# Altium schematic sheet 07_MOTOR_MAG.SchDoc
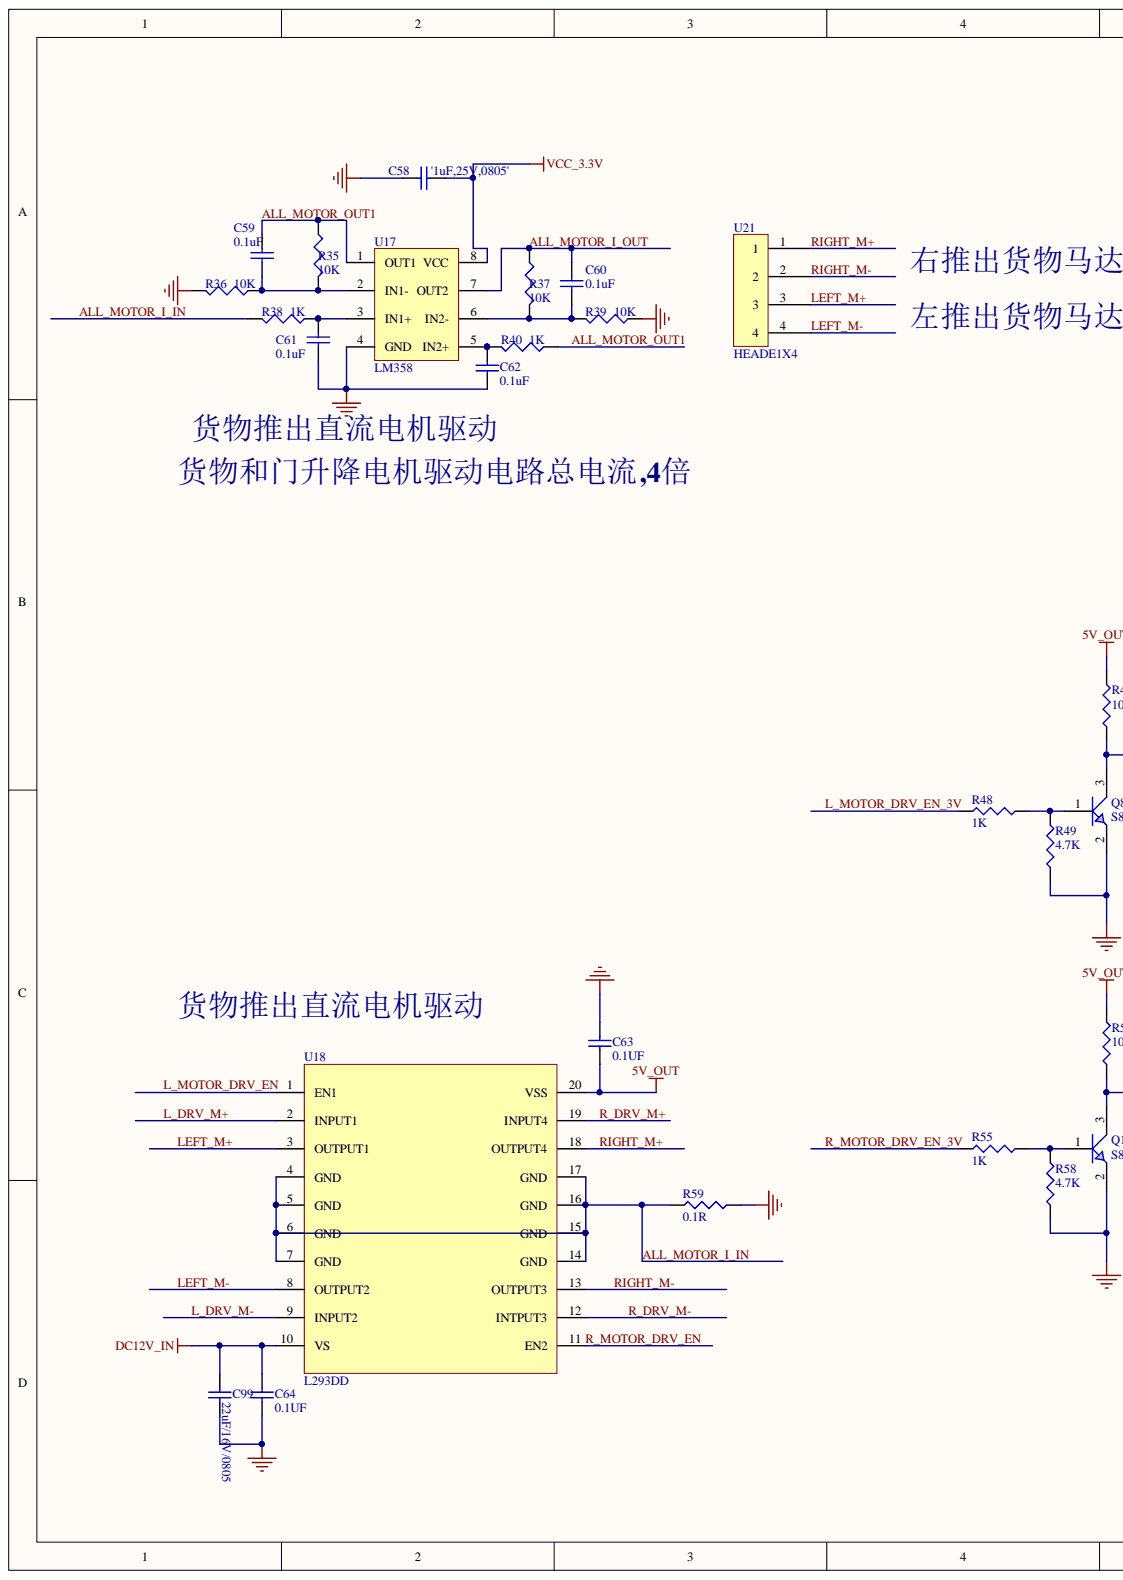
[diagram p0: 07_MOTOR_MAG.SchDoc, left]
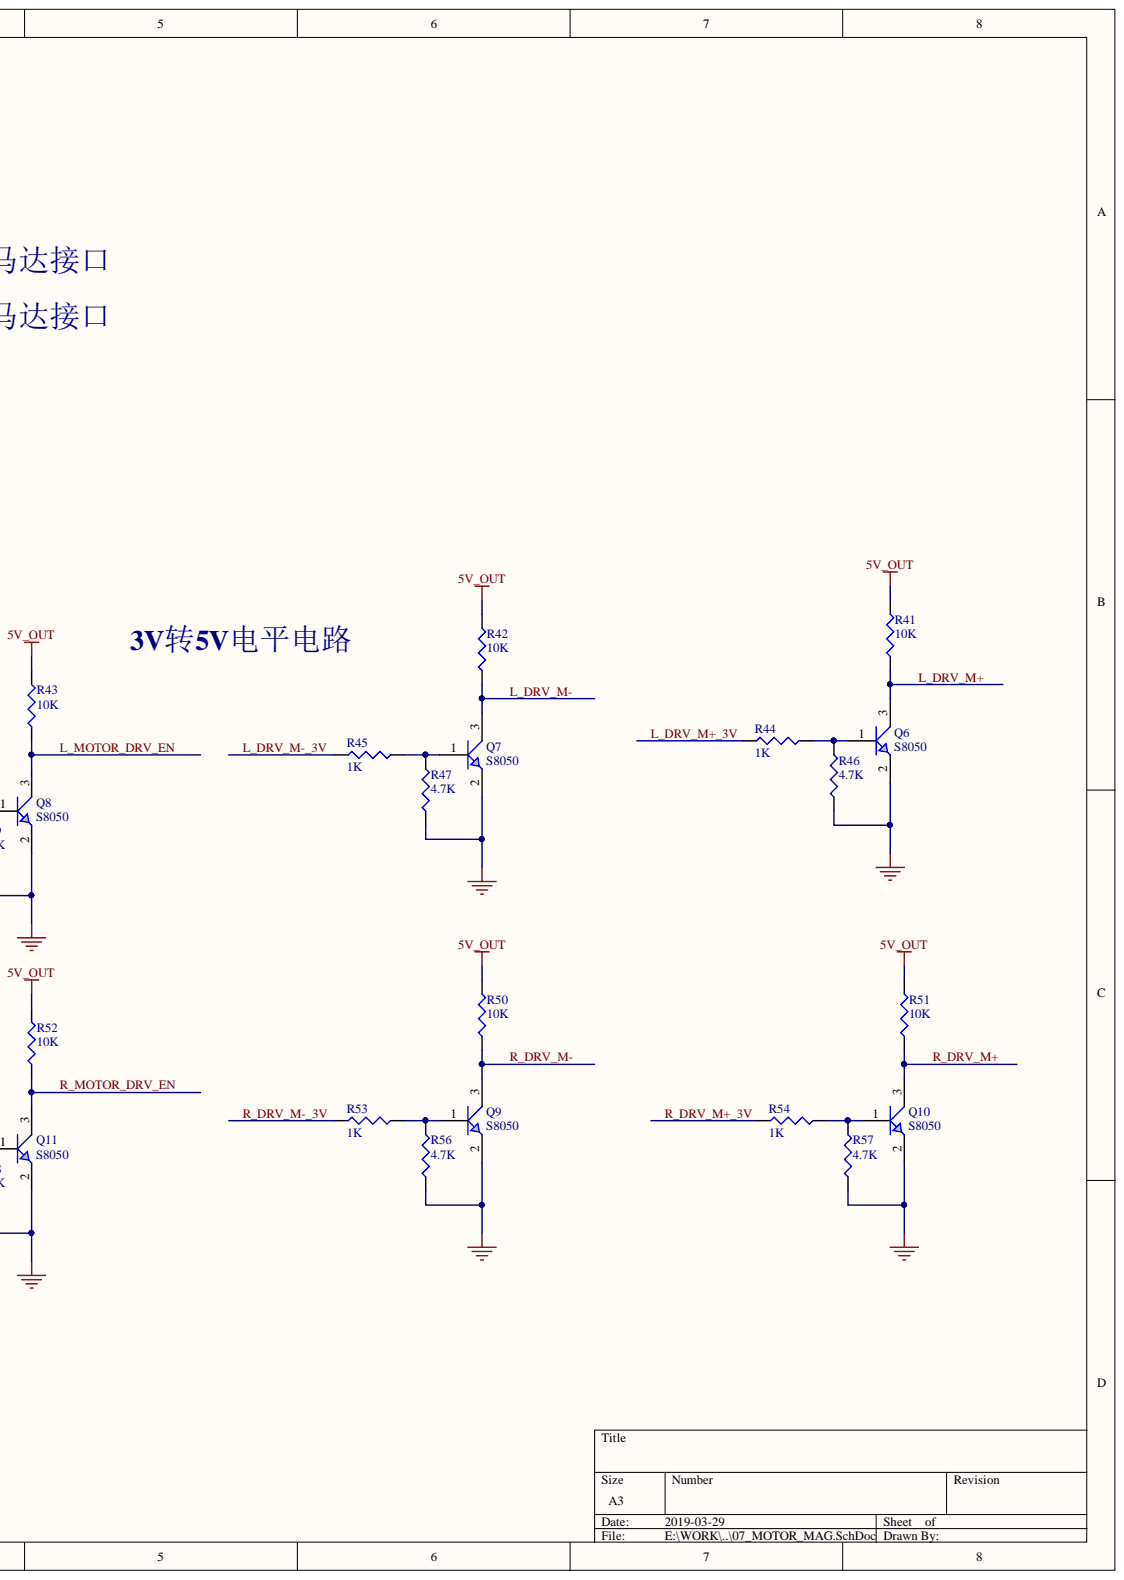
[diagram p1: 07_MOTOR_MAG.SchDoc, right]

## Parts
C58  value '1uF,25V,0805'  [0603_C]  (hidden comment: 1UF)
C59  0.1uF  [0603_C]
C60  0.1uF  [0603_C]
C61  0.1UF  [0603_C]
C62  0.1UF  [0603_C]
C63  0.1UF  [0603_C]
C64  0.1UF  [0603_C]
C99  22uF/16V/0805  [1206_C]
Q6  S8050  [SOT23]
Q7  S8050  [SOT23]
Q8  S8050  [SOT23]
Q9  S8050  [SOT23]
Q10  S8050  [SOT23]
Q11  S8050  [SOT23]
R35  10K  [0603_R]
R36  10K  [0603_R]
R37  10K  [0603_R]
R38  1K  [0603_R]
R39  10K  [0603_R]
R40  1K  [0603_R]
R41  10K  [0603_R]
R42  10K  [0603_R]
R43  10K  [0603_R]
R44  1K  [0603_R]
R45  1K  [0603_R]
R46  4.7K  [0603_R]
R47  4.7K  [0603_R]
R48  1K  [0603_R]
R49  4.7K  [0603_R]
R50  10K  [0603_R]
R51  10K  [0603_R]
R52  10K  [0603_R]
R53  1K  [0603_R]
R54  1K  [0603_R]
R55  1K  [0603_R]
R56  4.7K  [0603_R]
R57  4.7K  [0603_R]
R58  4.7K  [0603_R]
R59  0.1R  [1206_R]
U17  LM358  [SOP8]
U18  L293DD  [SOP20]
U21  value HEADE1X4  [HEADER1X4_1.25mm-V]  (hidden comment: IC)

## Nets
5V_OUT: C63.2 R41.2 R42.2 R43.2 R50.2 R51.2 R52.2 U18.20(VSS)
DC12V_IN: C64.2 C99.2 U18.10(VS)
GND: C58.1 C61.2 C62.2 C63.1 C64.1 C99.1 Q6.2 Q7.2 Q8.2 Q9.2 Q10.2 Q11.2 R36.2 R39.1 R46.1 R47.1 R49.1 R56.1 R57.1 R58.1 R59.1 U17.4(GND)
VCC_3.3V: C58.2 U17.8(VCC)
ALL_MOTOR_I_IN: R38.2 R59.2 U18.4(GND) U18.5(GND) U18.6(GND) U18.7(GND) U18.14(GND) U18.15(GND) U18.16(GND) U18.17(GND)
ALL_MOTOR_I_OUT: C60.1 R37.1 U17.7(OUT2)
ALL_MOTOR_OUT1: C59.1 R35.1 R40.1 U17.1(OUT1)
L_DRV_M+: Q6.3 R41.1 U18.2(INPUT1)
L_DRV_M+_3V: R44.1
L_DRV_M-: Q7.3 R42.1 U18.9(INPUT2)
L_DRV_M-_3V: R45.1
L_MOTOR_DRV_EN: Q8.3 R43.1 U18.1(EN1)
L_MOTOR_DRV_EN_3V: R48.1
LEFT_M+: U18.3(OUTPUT1) U21.3
LEFT_M-: U18.8(OUTPUT2) U21.4
R_DRV_M+: Q10.3 R51.1 U18.19(INPUT4)
R_DRV_M+_3V: R54.1
R_DRV_M-: Q9.3 R50.1 U18.12(INTPUT3)
R_DRV_M-_3V: R53.1
R_MOTOR_DRV_EN: Q11.3 R52.1 U18.11(EN2)
R_MOTOR_DRV_EN_3V: R55.1
RIGHT_M+: U18.18(OUTPUT4) U21.1
RIGHT_M-: U18.13(OUTPUT3) U21.2
NetC59_2: C59.2 R35.2 R36.1 U17.2(IN1-)
NetC60_2: C60.2 R37.2 R39.2 U17.6(IN2-)
NetC61_1: C61.1 R38.1 U17.3(IN1+)
NetC62_1: C62.1 R40.2 U17.5(IN2+)
NetQ6_1: Q6.1 R44.2 R46.2
NetQ7_1: Q7.1 R45.2 R47.2
NetQ8_1: Q8.1 R48.2 R49.2
NetQ9_1: Q9.1 R53.2 R56.2
NetQ10_1: Q10.1 R54.2 R57.2
NetQ11_1: Q11.1 R55.2 R58.2

## Library data of the parts
C58-C64 C99 = Cap Semi: Capacitor (Semiconductor SIM Model); Component Kind: Standard; LatestRevisionDate: 17-Jul-2002; LatestRevisionNote: Re-released for DXP Platform.; PackageReference: C1206; Published: 8-Jun-2000; Publisher: Altium Limited
  C59-C62: Value: 0.1uF
  C63 C64: Value: 0.1UF
  C99: Value: 22uF/16V/0805
R35-R40 = Res3: Resistor; Component Kind: Standard; LatestRevisionDate: 17-Jul-2002; LatestRevisionNote: Re-released for DXP Platform.; Published: 8-Jun-2000; Publisher: Altium Limited
  R35-R37 R39: Value: 10K
  R38 R40: Value: 1K
R41-R59 = Res: Component Kind: Standard; Library Name: Celestial.SchLib; Type: Res
U21 = HEADE1X4: Component Kind: Standard; Library Name: Jackson SCH.LIB
Q6-Q11 = S8050: Component Kind: Standard; Value: S8050; Library Name: Jackson SCH.LIB
U17 = LM358: Component Kind: Standard; Library Name: Jackson.LIB
U18 = L293DD: Component Kind: Standard; Value: L293DD; Library Name: Jackson SCH.LIB
